# Altium schematic sheet Signals.SchDoc(Signals)
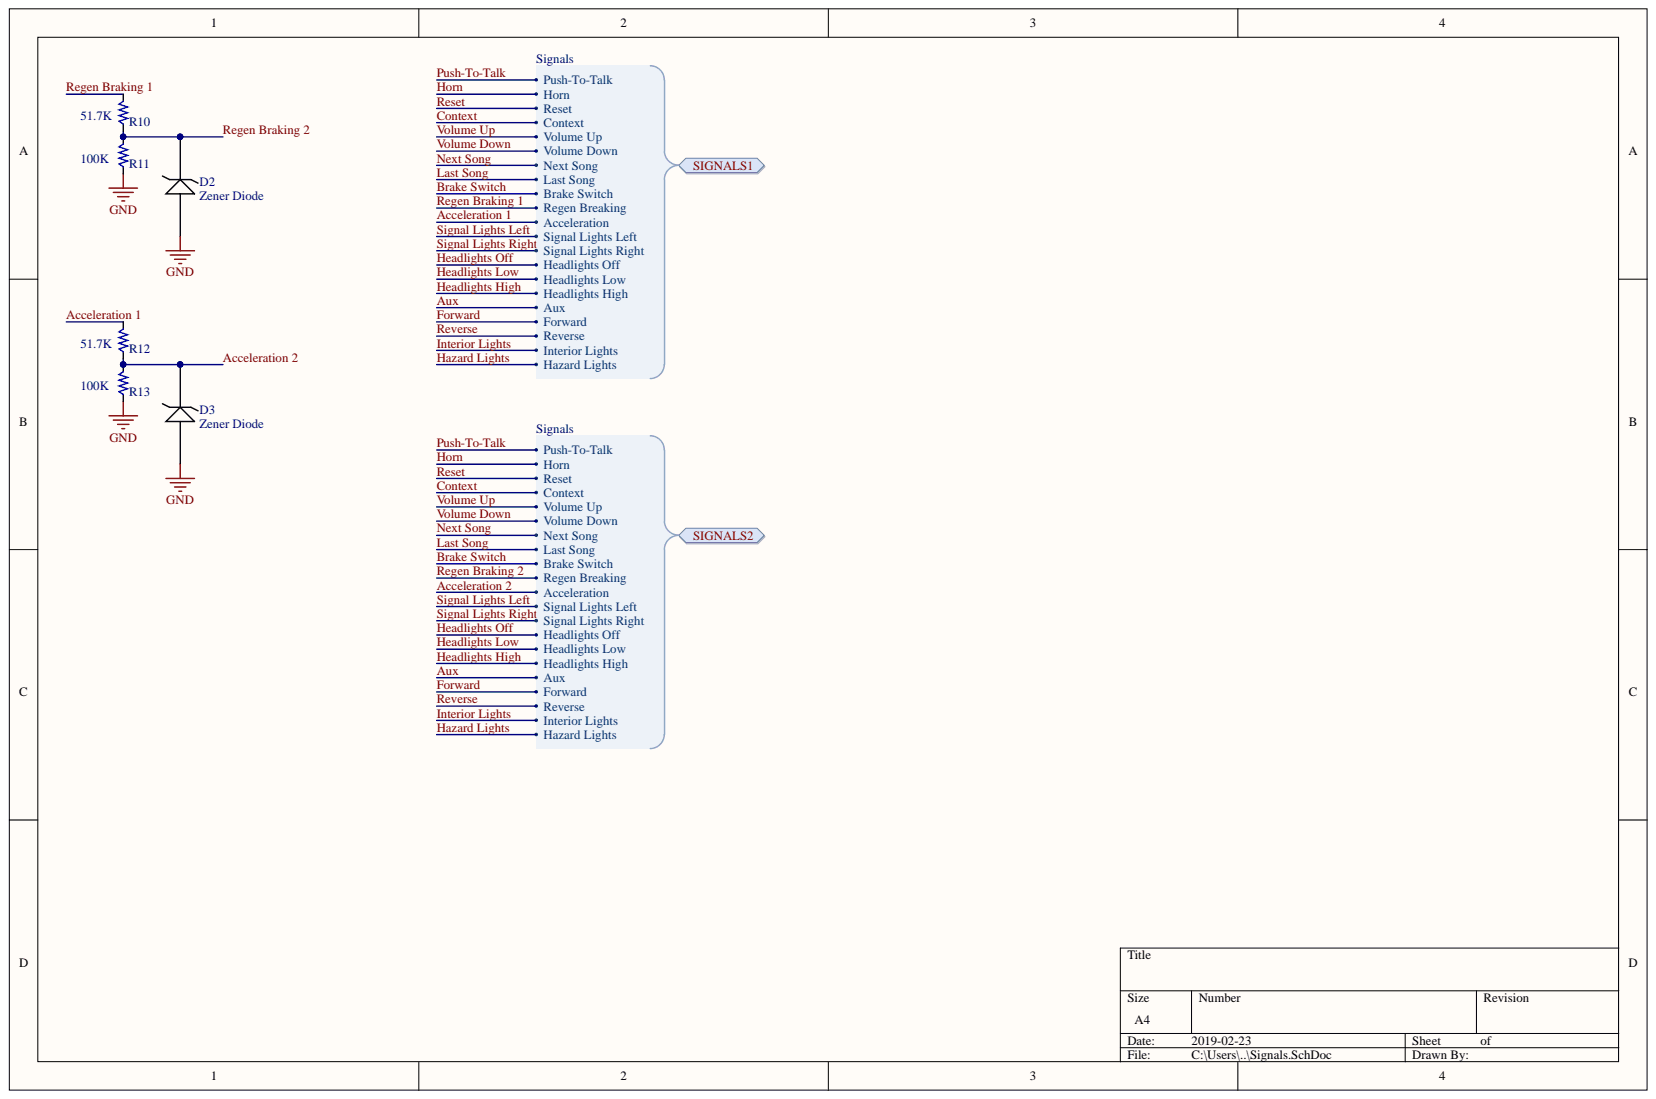
[diagram p0: Signals.SchDoc]

## Parts
D2  Zener Diode  [PCBComponent_1]
D3  Zener Diode  [PCBComponent_1]
R10  Resistance: 51.7K  [PCB_GENERIC_SMD_0603]  (hidden comment: SCH_GENERIC_RESISTOR_0603)
R11  Resistance: 100K  [PCB_GENERIC_SMD_0603]  (hidden comment: SCH_GENERIC_RESISTOR_0603)
R12  Resistance: 51.7K  [PCB_GENERIC_SMD_0603]  (hidden comment: SCH_GENERIC_RESISTOR_0603)
R13  Resistance: 100K  [PCB_GENERIC_SMD_0603]  (hidden comment: SCH_GENERIC_RESISTOR_0603)

## Ports
SIGNALS1, SIGNALS1.Acceleration, SIGNALS1.Aux, SIGNALS1.Brake Switch, SIGNALS1.Context, SIGNALS1.Forward, SIGNALS1.Hazard Lights, SIGNALS1.Headlights High, SIGNALS1.Headlights Low, SIGNALS1.Headlights Off, SIGNALS1.Horn, SIGNALS1.Interior Lights, SIGNALS1.Last Song, SIGNALS1.Next Song, SIGNALS1.Push-To-Talk, SIGNALS1.Regen Breaking, SIGNALS1.Reset, SIGNALS1.Reverse, SIGNALS1.Signal Lights Left, SIGNALS1.Signal Lights Right, SIGNALS1.Volume Down, SIGNALS1.Volume Up, SIGNALS2, SIGNALS2.Acceleration, SIGNALS2.Aux, SIGNALS2.Brake Switch, SIGNALS2.Context, SIGNALS2.Forward, SIGNALS2.Hazard Lights, SIGNALS2.Headlights High, SIGNALS2.Headlights Low, SIGNALS2.Headlights Off, SIGNALS2.Horn, SIGNALS2.Interior Lights, SIGNALS2.Last Song, SIGNALS2.Next Song, SIGNALS2.Push-To-Talk, SIGNALS2.Regen Breaking, SIGNALS2.Reset, SIGNALS2.Reverse, SIGNALS2.Signal Lights Left, SIGNALS2.Signal Lights Right, SIGNALS2.Volume Down, SIGNALS2.Volume Up

## Nets
GND: D2.2 D3.2 R11.1 R13.1
Acceleration 1: R12.2 ; port SIGNALS1
Acceleration 2: D3.1 R12.1 R13.2 ; port SIGNALS2
Regen Braking 1: R10.2 ; port SIGNALS1
Regen Braking 2: D2.1 R10.1 R11.2 ; port SIGNALS2
Aux: ; port SIGNALS1, port SIGNALS2
Brake Switch: ; port SIGNALS1, port SIGNALS2
Context: ; port SIGNALS1, port SIGNALS2
Forward: ; port SIGNALS1, port SIGNALS2
Hazard Lights: ; port SIGNALS1, port SIGNALS2
Headlights High: ; port SIGNALS1, port SIGNALS2
Headlights Low: ; port SIGNALS1, port SIGNALS2
Headlights Off: ; port SIGNALS1, port SIGNALS2
Horn: ; port SIGNALS1, port SIGNALS2
Interior Lights: ; port SIGNALS1, port SIGNALS2
Last Song: ; port SIGNALS1, port SIGNALS2
Next Song: ; port SIGNALS1, port SIGNALS2
Push-To-Talk: ; port SIGNALS1, port SIGNALS2
Reset: ; port SIGNALS1, port SIGNALS2
Reverse: ; port SIGNALS1, port SIGNALS2
Signal Lights Left: ; port SIGNALS1, port SIGNALS2
Signal Lights Right: ; port SIGNALS1, port SIGNALS2
Volume Down: ; port SIGNALS1, port SIGNALS2
Volume Up: ; port SIGNALS1, port SIGNALS2

## Library data of the parts
D2 D3 = ON Semi Schottky Diode - MBRM110LT1G: Library Name: ZenerDiode.SchLib; Component Kind: Standard
R10-R13 = SCH_GENERIC_RESISTOR_0603: Generic SMD resistor 0603; Library Name: Generic 0603 Resistor.SchLib; Component Kind: Standard
